# Altium schematic sheet NanoD1_Clk_Reset.SchDoc
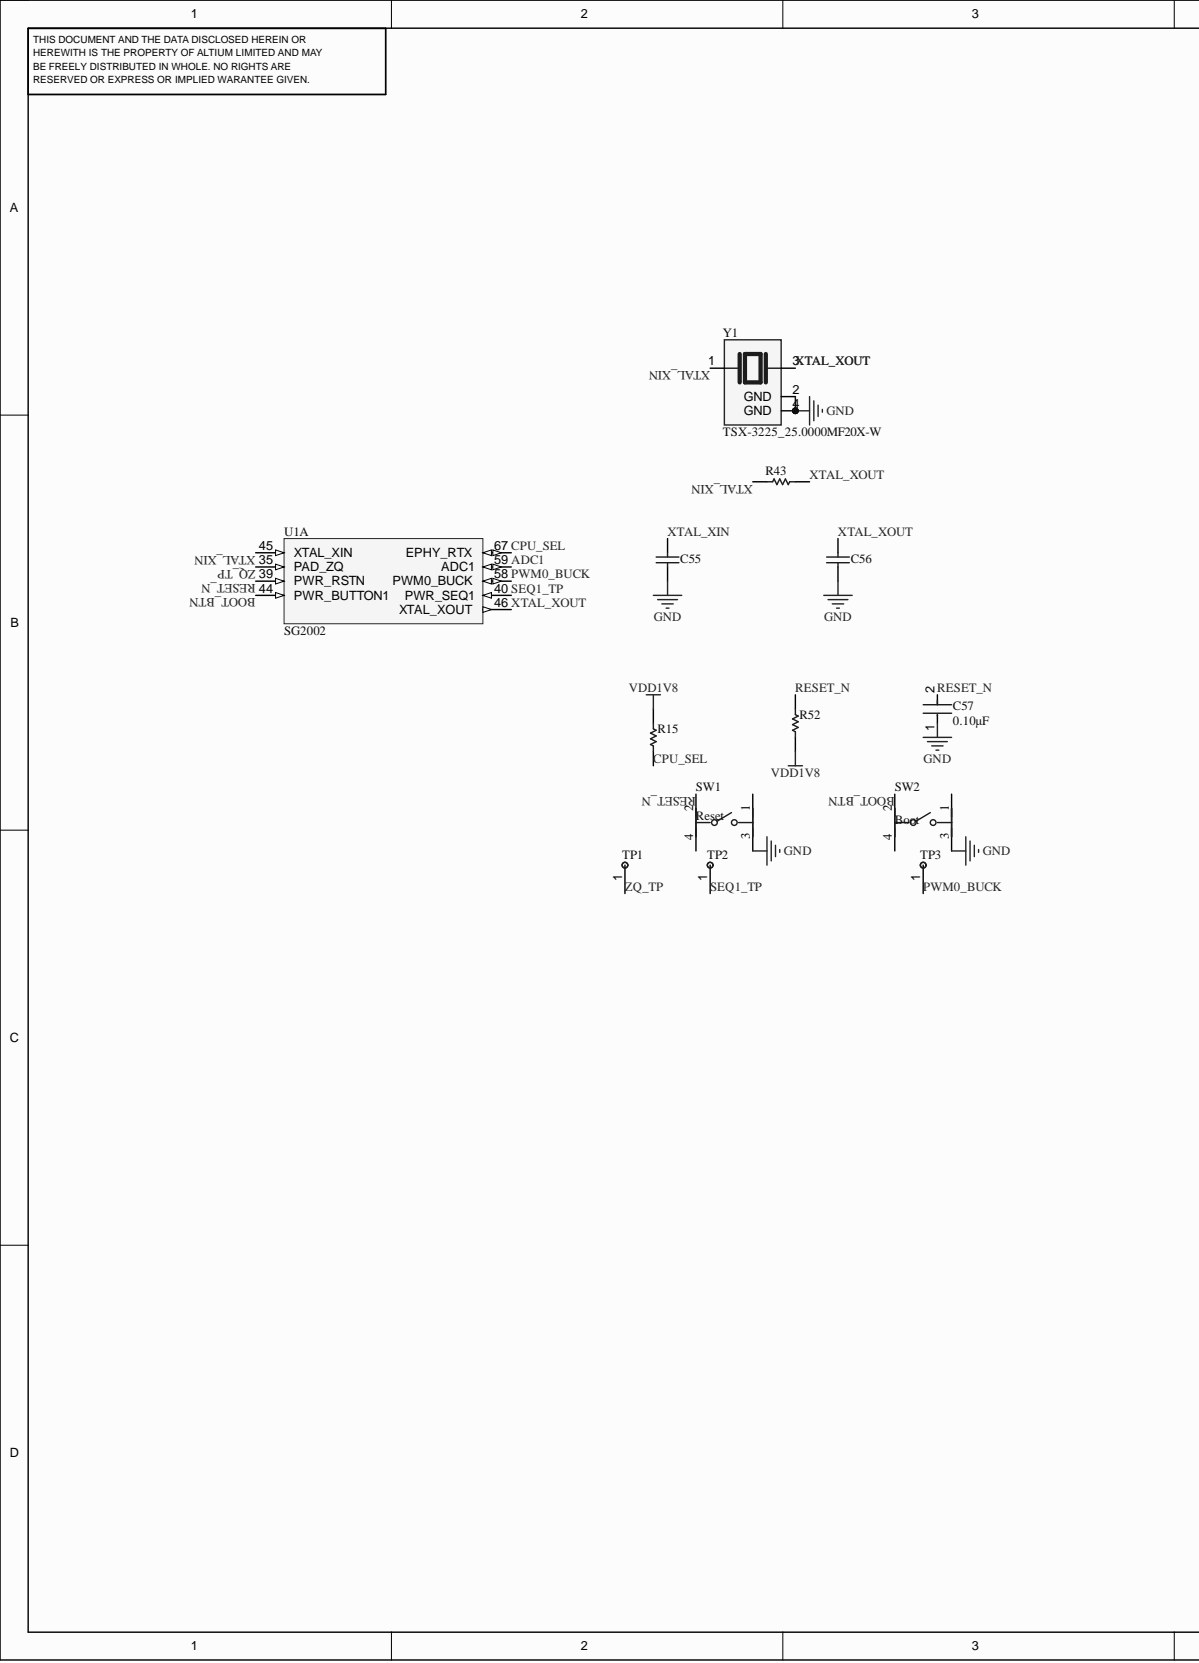
[diagram p0: NanoD1_Clk_Reset.SchDoc, left]
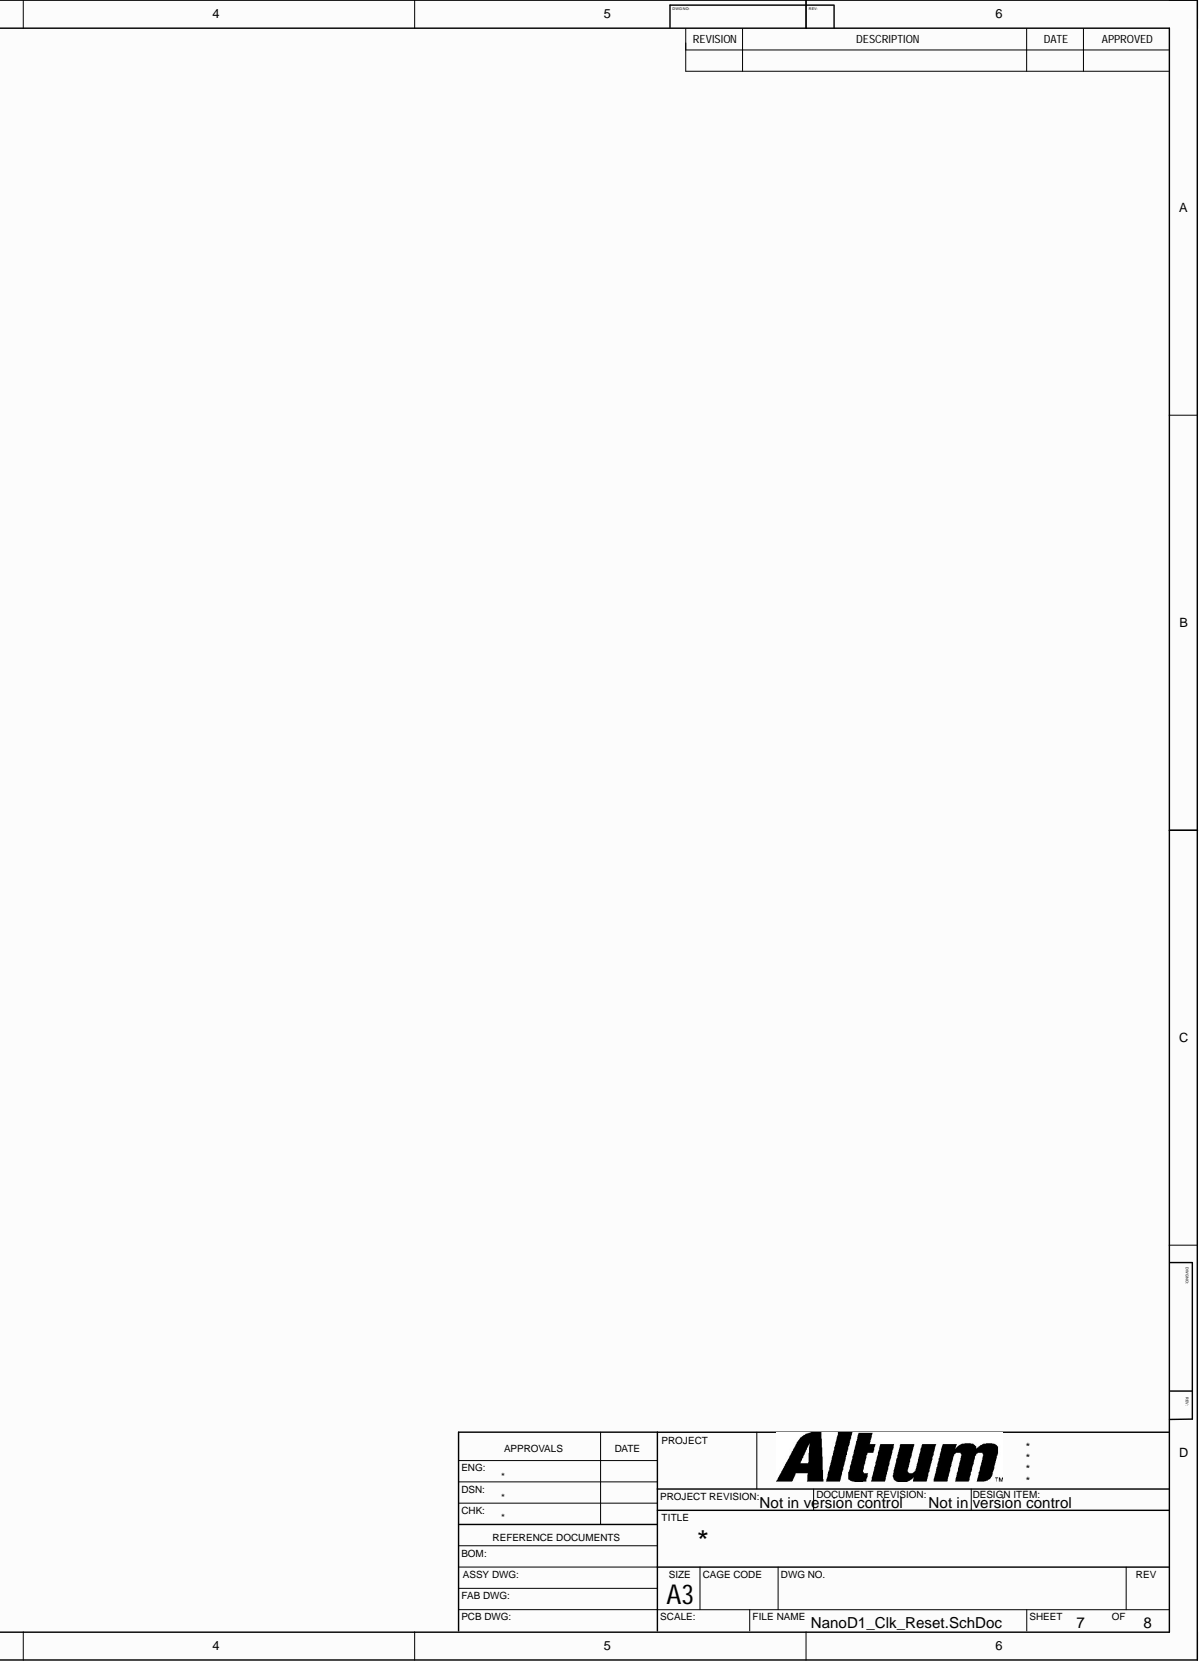
[diagram p1: NanoD1_Clk_Reset.SchDoc, right]

## Parts
C55  18pF  [CAPC1005X55X25LL05T10]  (value not drawn)
C56  18pF  [CAPC1005X55X25LL05T10]  (value not drawn)
C57  value 0.10μF  Capacitance: 0.10μF  [FP-GRM15-MFG]  (hidden comment: 100nF)
R15  4.7K  [RESC1005X40X25ML05T10]  (value not drawn)
R43  1M  [RESC1005X40X25ML05T10]  (value not drawn)
R52  10K  [RESC1005X40X25ML05T10]  (value not drawn)
SW1  Reset  [FP-EVQ-P2002M-MFG]
SW2  Boot  [FP-EVQ-P2002M-MFG]
TP1  TP  [TP-1.5mm]
TP2  TP  [TP-1.5mm]
TP3  TP  [TP-1.5mm]
U1  SG2002  [QFN88-9x9-0.35]  (part A)
Y1  TSX-3225_25.0000MF20X-W  [XTAL_TSX-3225_25.0000MF20X-W]

## Nets
GND: C55.1 C56.1 C57.1 SW1.3 SW2.3 Y1.2(GND) Y1.4(GND)
VDD1V8: R15.2 R52.1
ADC1: U1.59(ADC1)
BOOT_BTN: SW2.2 U1.44(PWR_BUTTON1)
CPU_SEL: R15.1 U1.67(EPHY_RTX)
PWM0_BUCK: TP3.1 U1.58(PWM0_BUCK)
RESET_N: C57.2 R52.2 SW1.2 U1.39(PWR_RSTN)
SEQ1_TP: TP2.1 U1.40(PWR_SEQ1)
XTAL_XIN: C55.2 R43.2 U1.45(XTAL_XIN) Y1.1
XTAL_XOUT: C56.2 R43.1 U1.46(XTAL_XOUT) Y1.3
ZQ_TP: TP1.1 U1.35(PAD_ZQ)

Not connected: SW1 1 4; SW2 1 4

## Library data of the parts
C55 C56 = CMP-2008-03918-1: Manufacturer: Murata; Part Number: GRM1555C1H180JA01D; Component Kind: Standard; Library Name: GRM1555C1H180JA01D.IntLib
C57 = CMP-2100-03641-2: CAP CER 0.1UF 16V X7R 0402; Manufacturer: Murata; Manufacturer Part Number: GRM155R71C104KA88D; Material: Ceramic; Standoff Height: 0mm; Tolerance: 10%; Voltage Rating: 16Vdc; Category: Cap; Component Kind: Standard; ComponentLink2Description: Datasheet; ComponentLink2URL: https://search.murata.co.jp/Ceramy/image/img/A01X/G101/ENG/GRM155R71H471KA01-01A.pdf; Device Class L1: Passive Components; Device Class L2: Capacitors; Device Class L3: Ceramic Capacitors; Digikey Description: CAP CER 0.1UF 16V X7R 0402; Height: 0.55mm; Ipc Land Pattern Name: CAPC10050X219; Lead Free: yes; Library Name: GRM155R71C104KA88D.IntLib; Mouser Description: 0402 0.1 uF 16V ±10 % Tolerance X7R SMT Multilayer Ceramic Capacitor; Octopart: https://octopart.com/grm155r71c104ka88d-murata-196880; Package: GRM15; RoHS: TRUE; Temperature: -55°C to +125°C; Temperature Characteristic: X7R; Temperature Range High: +125°C; Temperature Range Low: -55°C
R15 = CMP-1011-00586-2: Manufacturer: Yageo / Phycomp; Part Number: RC0402FR-074K7L; Component Kind: Standard; Library Name: RC0402FR-074K7L.IntLib
R43 = CMP-2002-07490-1: Manufacturer: Yageo / Phycomp; Part Number: RC0402FR-071ML; Component Kind: Standard; Library Name: RC0402FR-071ML.IntLib
R52 = CMP-2002-07353-1: Manufacturer: Yageo / Phycomp; Part Number: RC0402FR-0710KL; Component Kind: Standard; Library Name: RC0402FR-0710KL.IntLib
SW1 SW2 = CMP-00287-40010164-1: EVQ-P2002M Switch Tactile N.O. SPST Button J-Bend 0.02A 15VDC 1N SMD Automotive Embossed T/R; Actuator Length: 450µm; Contact Current Rating: 20mA; Contact Resistance: 100mΩ; Electrical Life: 1Mcycles; Illumination Type: Illuminated, Non-Illuminated; Max Voltage Rating (DC): 15V; Number of Positions: 2; Switch Function: Off-Mom; Switch Travel: 250µm; Component Kind: Standard; Current Rating: 20mA; Height: 2.3mm; Lead Free: Lead Free; Library Name: EVQ-P2002M.IntLib; Life (Cycles): 1Mcycles; Max Operating Temperature: 85°C; Mechanical Life: 1Mcycles; Min Operating Temperature: -40°C; Mount: Surface Mount; NexarPartId: 40010164; Operating Force: 1N; Orientation: Straight; Radiation Hardening: No; REACH SVHC: No SVHC; RoHS: Compliant; Termination: J-Bend; Throw Configuration: SPST; Voltage Rating (DC): 15V
TP1-TP3 = TestPoint: Test point, 1.5 mm exposed pad; Component Kind: Standard; Library Name: NanoD1.SchLib
U1 = SG2002: RISC-V C906 / Cortex-A53 SoC, 256MB DDR3 SiP, QFN88 9x9 0.35mm; Component Kind: Standard; Library Name: NanoD1.SchLib
Y1 = TSX-3225_25.0000MF20X-W: MF: {'manufacturer': u'Epson Timing'}; MP: TSX-3225 25.0000MF20X-W; Availability: Unavailable; Component Kind: Standard; Library Name: TSX-3225_25.0000MF20X-W.IntLib; Package: None; Price: None
